# Altium schematic sheet CMoy.breadboard.SchDoc
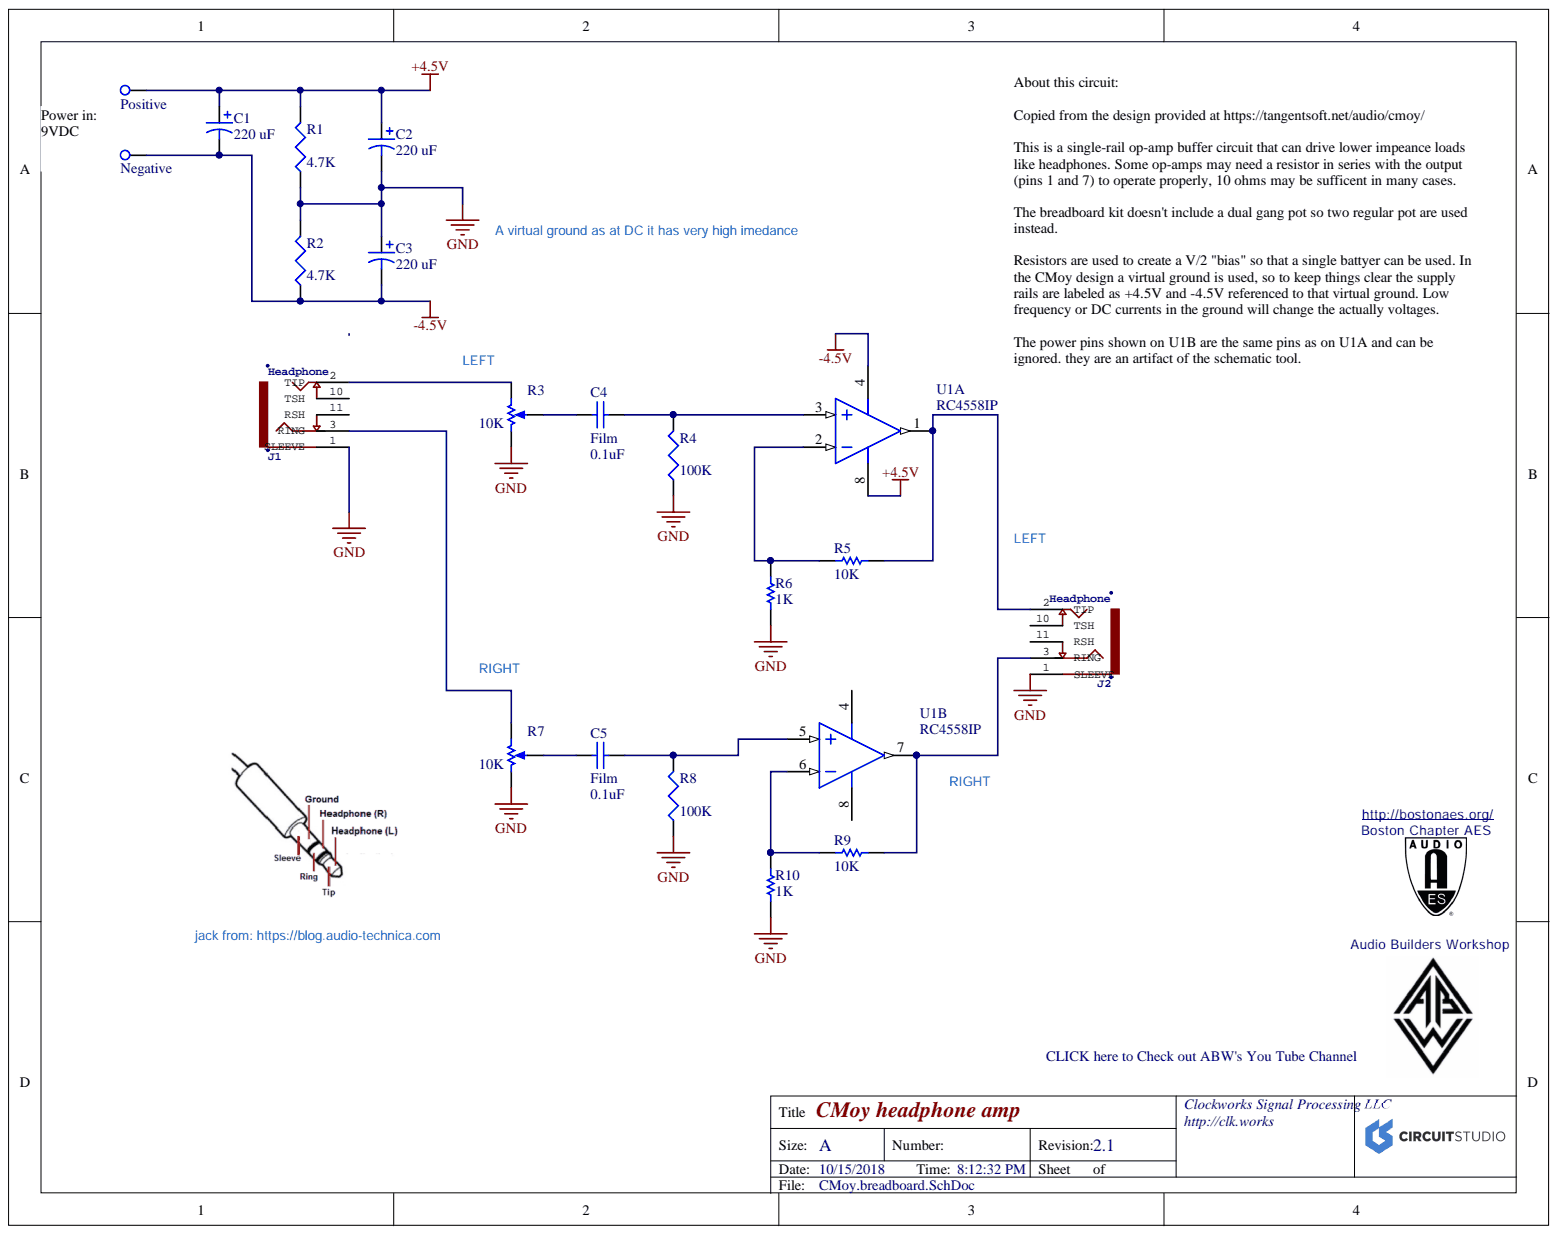
[diagram p0: CMoy.breadboard.SchDoc]

## Parts
C1  value 220 uF  [RB7.6-15]  (hidden comment: Cap Pol1)
C2  value 220 uF  [RB7.6-15]  (hidden comment: Cap Pol1)
C3  value 220 uF  [RB7.6-15]  (hidden comment: Cap Pol1)
C4  Film  value 0.1uF  [RAD-0.3]
C5  Film  value 0.1uF  [RAD-0.3]
J1  Headphone  [SWITCHCRAFT_35RAPC4BHN2]
J2  Headphone  [SWITCHCRAFT_35RAPC4BHN2]
R1  value 4.7K  [J1-0603]  (hidden comment: Res3)
R2  value 4.7K  [J1-0603]  (hidden comment: Res3)
R3  value 10K  [VR5]  (hidden comment: RPot)
R4  value 100K  [J1-0603]  (hidden comment: Res3)
R5  10K  [SL Axial 10k 1/4W]
R6  1K  [SL Axial 1k 1/4W]
R7  value 10K  [VR5]  (hidden comment: RPot)
R8  value 100K  [J1-0603]  (hidden comment: Res3)
R9  10K  [SL Axial 10k 1/4W]
R10  1K  [SL Axial 1k 1/4W]
TP1  Positive  [SL TEST POINT]  (no BOM)
TP2  Negative  [SL TEST POINT]  (no BOM)
U1  RC4558IP  [P0008A]  (parts A,B)

## Nets
+4.5V: C1.1 C2.1 R1.2 TP1.1 U1.8
-4.5V: C1.2 C3.2 R2.1 TP2.1 U1.4
GND: C2.2 C3.1 J1.1(SLEEVE) J2.1(SLEEVE) R1.1 R2.2 R3.2 R4.1 R6.1 R7.2 R8.1 R10.1
NetC4_1: C4.1 R3.3
NetC4_2: C4.2 R4.2 U1.3
NetC5_1: C5.1 R7.3
NetC5_2: C5.2 R8.2 U1.5
NetJ1_2: J1.2(TIP) R3.1
NetJ1_3: J1.3(RING) R7.1
NetJ2_2: J2.2(TIP) R5.2 U1.1
NetJ2_3: J2.3(RING) R9.2 U1.7
NetR5_1: R5.1 R6.2 U1.2
NetR9_1: R9.1 R10.2 U1.6
N00004:

Not connected: J1 10(TSH) 11(RSH); J2 10(TSH) 11(RSH)

## Library data of the parts
C1-C3 = Cap Pol1: Polarized Capacitor (Radial); Component Kind: Standard; LatestRevisionDate: 17-Jul-2002; LatestRevisionNote: Re-released for DXP Platform.; Library Name: Miscellaneous Devices.IntLib; PackageDescription: Polarized Capacitor (Radial); 2 Leads; PackageReference: RB7.6-15; Published: 8-Jun-2000; Simulation: CAP; Publisher: Altium Limited
C4 C5 = Cap: Capacitor; Component Kind: Standard; LatestRevisionDate: 17-Jul-2002; LatestRevisionNote: Re-released for DXP Platform.; Library Name: Miscellaneous Devices.IntLib; PackageDescription: Radial Cap, Thru-Hole; 2 Leads; 0.3 in Pin Spacing; PackageReference: RAD-0.3; Published: 8-Jun-2000; Simulation: CAP; Publisher: Altium Limited
J1 J2 = CSP-J0022-A: Conn 3.5MM Stereo Jack F 5 POS Solder RA Thru-Hole 5 Terminal 1 Port; CSPManufacturer 1: Switchcraft; CSPID: J0022; DeviceSetName: 35RAPC4BHN2; GateName_1: G$1; Availability: Good; Component Kind: Standard; Library Name: CSP.J.connectors.IntLib; LibraryName: 35RAPC4BHN2; Package: None; PartNumber 1: 35RAPC4BHN2; Price: 1.00 USD; Supplier 1: Newark; Supplier 2: Mouser; Supplier 3: Digi-Key; Supplier Part Number 1: 96F9607; Supplier Part Number 2: 502-35RAPC4BHN2; Supplier Part Number 3: SC1459-ND; SymbolName_1: 35RAPC4BHN2
R1 R2 R4 R8 = Res3: Resistor; Component Kind: Standard; LatestRevisionDate: 29-May-2009; LatestRevisionNote: IPC-7351 Footprint Added.; Library Name: Miscellaneous Devices.IntLib; PackageDescription: Chip Resistor; PackageReference: J1-0603; Published: 8-Jun-2000; Simulation: RESISTOR; Publisher: Altium Limited
R3 R7 = RPot: Potentiometer; Set Position: 0.5; Sim Note: Enter the Resistance value and the set point into parameter fields.; Component Kind: Standard; LatestRevisionDate: 17-Jul-2002; LatestRevisionNote: Re-released for DXP Platform.; Library Name: Miscellaneous Devices.IntLib; PackageDescription: Variable Resistor, Thru-Hole; 3 Leads; PackageReference: VR5; Published: 8-Jun-2000; Simulation: POT; Publisher: Altium Limited
R5 R9 = SL-R0020-A: 10K 1/4W TH; Partnumber 1: CF14JT10K0; CSPID: R0020; Component Kind: Standard; Supplier 1: Newark; Supplier 2: Digi-Key; Supplier Part Number 1: 78Y4255; Supplier Part Number 2: CF14JT10K0CT-ND; Value: 10K; Library Name: met.IntLib
R6 R10 = SL-R0016-A: 1K 1/4W TH; CSPID: R0016; Component Kind: Standard; Supplier 1: Digi-Key; Supplier Part Number 1: CF14JT1K00CT-ND; Value: 1K; Library Name: met.IntLib
TP1 TP2 = SL-X0005-A: Connection point; CSPID: X0005; Library Name: met.IntLib
U1 = CMP-0017-00906-2: Dual General-Purpose Operational Amplifier, 10 to 30 V, -40 to 85 degC, 8-pin DIP (P8), Pb-Free (RoHS); Manufacturer: Texas Instruments; CMRR(Min)(dB): 70; GBW(Typ)(MHz): 3; IIB(Max)(pA): 500000; Iq per channel(Max)(mA): 2.8; Number of Channels: 2; Slew Rate(Typ)(V/us): 1.7; Sub Family: General Purpose Amplifier, High Supply Voltage Amplifier (>=30V), Low Noise Amp (<=10nV/rtHz); Total Supply Voltage(Max)(+5V is 5, +/-5V is 10): 30; Total Supply Voltage(Min)(+5V is 5, +/-5V is 10): 10; Vio (25C)(Max)(mV): 6; Vn at 1kHz(Typ)(nV/rtHz): 8; Code_JEDEC: MS-001; Component Kind: Standard; ComponentLink1Description: Manufacturer URL; ComponentLink1URL: http://www.ti.com/; ComponentLink2Description: Datasheet; ComponentLink2URL: http://www.ti.com/lit/ds/symlink/rc4558.pdf; ComponentLink3Description: Package Specification; ComponentLink3URL: http://www.ti.com/litv/pdf/mpdi001b; DatasheetVersion: SLOS073F; Eco-Plan: Pb-Free (RoHS); Library Name: Altium Content Vault; Mounting Technology: Through Hole; PackageDescription: 8-Pin Plastic In-Line, Body 9.59 x 6.35 mm, Pitch 2.54 mm; PackageReference: P0008A; PackageVersion: 4040082/E, 04/2010; PartNumber: RC4558IP
